# Altium schematic sheet ADC.SchDoc
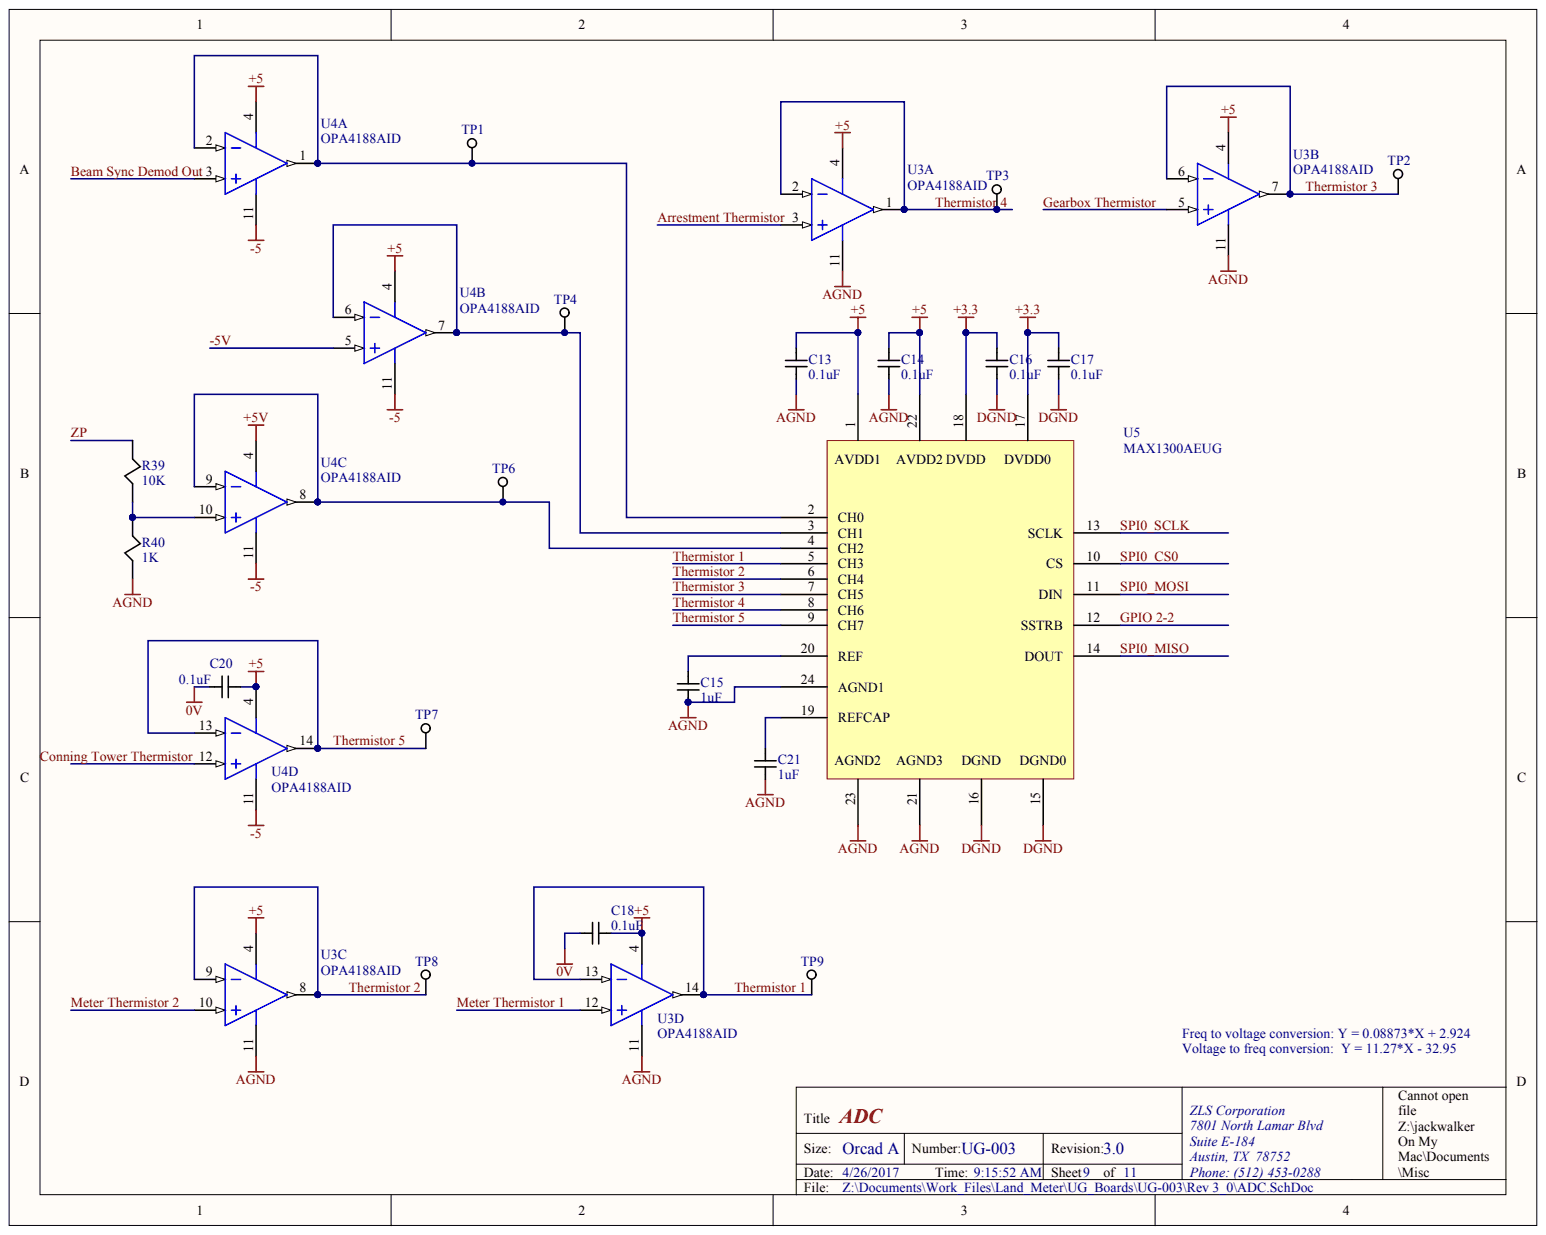
[diagram p0: ADC.SchDoc]

## Parts
C13  0.1uF
C14  0.1uF
C15  1uF
C16  0.1uF
C17  0.1uF
C18  0.1uF
C20  0.1uF
C21  1uF
R39  10K
R40  1K
TP1  (no value)
TP2  (no value)
TP3  (no value)
TP4  (no value)
TP6  (no value)
TP7  (no value)
TP8  (no value)
TP9  (no value)
U3  OPA4188AID  (parts A,B,C,D)
U4  OPA4188AID  (parts A,B,C,D)
U5  MAX1300AEUG

## Nets
0V: C18.1 C20.1
+3.3: C16.1 C17.1 U5.17 U5.18
+5: C13.1 C14.1 C18.2 C20.2 U3.4 U4.4 U5.1 U5.22
+5V: U4.4
-5: U4.11(-5)
AGND: C13.2 C14.2 C15.2 C21.2 R40.1 U3.11 U5.21 U5.23 U5.24(AGND1)
DGND: C16.2 C17.2 U5.15 U5.16
-5V: U4.5
ARRESTMENT THERMISTOR: U3.3
BEAM SYNC DEMOD OUT: U4.3
GEARBOX THERMISTOR: U3.5
GPIO 2-2: U5.12(SSTRB)
METER THERMISTOR 1: U3.12
METER THERMISTOR 2: U3.10
SPI0_CS0: U5.10(CS)
SPI0_MISO: U5.14(DOUT)
SPI0_MOSI: U5.11(DIN)
SPI0_SCLK: U5.13(SCLK)
THERMISTOR 1: TP9.1 U3.13 U3.14 U5.5(CH3)
THERMISTOR 2: TP8.1 U3.8 U3.9 U5.6(CH4)
THERMISTOR 3: TP2.1 U3.6 U3.7 U5.7(CH5)
THERMISTOR 4: TP3.1 U3.1 U3.2 U5.8(CH6)
THERMISTOR 5: TP7.1 U4.13 U4.14 U5.9(CH7)
ZP: R39.2
NetC15_1: C15.1 U5.20(REF)
NetC21_1: C21.1 U5.19(REFCAP)
NetR39_1: R39.1 R40.2 U4.10
NetTP1_1: TP1.1 U4.1 U4.2 U5.2(CH0)
NetTP4_1: TP4.1 U4.6 U4.7 U5.3(CH1)
NetTP6_1: TP6.1 U4.8 U4.9 U5.4(CH2)
CONNING TOWER THERMISTOR:

Not connected: U4 12

## Library data of the parts
C13 C14 C16-C18 C20 = (no symbol name): Manufacturer 1: Murata Electronics North America; Manufacturer Part Number 1: GRM21BR71E104KA01L; Description 1: CAP CER 0.1UF 25V X7R 0805; Category 1: Capacitors; Capacitance: 0.1µF; Tolerance: ±10%; Voltage - Rated: 25V; Temperature Coefficient: X7R; Supplier 1: Digi-Key; Supplier Part Number 1: 490-1673-1-ND; RoHS 1: RoHS Compliant; Stock 1: 897437; Pricing 1: 1=0.2, 10=0.145, 25=0.106, 50=0.0824, 100=0.0683, 250=0.05652, 500=0.04788, 1000=0.03964 (USD); Packaging: Cut Tape (CT); Part Status: Active; Operating Temperature: -55°C ~ 125°C; Features: -; Ratings: -; Applications: General Purpose; Mounting Type: Surface Mount, MLCC; Package / Case: 0805 (2012 Metric); Size / Dimension: 0.079" L x 0.049" W (2.00mm x 1.25mm); Height - Seated (Max): -; Thickness (Max): 0.053" (1.35mm); Lead Spacing: -; Lead Style: -; ComponentLink1URL: http://www.murata.com/~/media/webrenewal/support/library/catalog/products/capacitor/mlcc/c02e.ashx; ComponentLink1Description: http://www.murata.com/~/media/webrenewal/support/library/catalog/products/capacitor/mlcc/c02e.ashx
C15 C21 = (no symbol name): Manufacturer 1: Murata Electronics North America; Manufacturer Part Number 1: GRM219R71C104KA01D; Description 1: CAP CER 0.1UF 16V X7R 0805; Category 1: Capacitors; Capacitance: 0.1µF; Tolerance: ±10%; Voltage - Rated: 16V; Temperature Coefficient: X7R; Supplier 1: Digi-Key; Supplier Part Number 1: 490-1683-1-ND; RoHS 1: RoHS Compliant; Stock 1: 267626; Pricing 1: 1=0.13, 10=0.095, 25=0.0688, 50=0.0536, 100=0.0445, 250=0.0368, 500=0.03118, 1000=0.02581 (USD); Packaging: Cut Tape (CT); Part Status: Active; Operating Temperature: -55°C ~ 125°C; Features: -; Ratings: -; Applications: General Purpose; Mounting Type: Surface Mount, MLCC; Package / Case: 0805 (2012 Metric); Size / Dimension: 0.079" L x 0.049" W (2.00mm x 1.25mm); Height - Seated (Max): -; Thickness (Max): 0.037" (0.95mm); Lead Spacing: -; Lead Style: -; ComponentLink1URL: http://www.murata.com/~/media/webrenewal/support/library/catalog/products/capacitor/mlcc/c02e.ashx; ComponentLink1Description: http://www.murata.com/~/media/webrenewal/support/library/catalog/products/capacitor/mlcc/c02e.ashx
R39 = (no symbol name): Manufacturer 1: Vishay Dale; Manufacturer Part Number 1: CRCW080510K0FKTA; Description 1: RES SMD 10K OHM 1% 1/8W 0805; Category 1: Resistors; Tolerance: ±1%; Power (Watts): 0.125W, 1/8W; Temperature Coefficient: ±100ppm/°C; Supplier 1: Digi-Key; Supplier Part Number 1: 541-3016-1-ND; RoHS 1: RoHS non-compliant; Stock 1: 11388; Pricing 1: 1=0.14, 10=0.123, 25=0.0852, 50=0.0662, 100=0.0474, 250=0.03692, 500=0.02936, 1000=0.02084 (USD); Packaging: Cut Tape (CT); Part Status: Active; Resistance (Ohms): 10k; Composition: Thick Film; Features: Automotive AEC-Q200; Operating Temperature: -55°C ~ 155°C; Package / Case: 0805 (2012 Metric); Supplier Device Package: 0805; Size / Dimension: 0.079" L x 0.049" W (2.00mm x 1.25mm); Height - Seated (Max): 0.020" (0.50mm); Failure Rate: -; ComponentLink1URL: http://www.vishay.com/docs/20008/dcrcw.pdf; ComponentLink1Description: http://www.vishay.com/docs/20008/dcrcw.pdf
R40 = (no symbol name): Manufacturer 1: Vishay Dale; Manufacturer Part Number 1: CRCW080510K0FKEC; Description 1: RES SMD 10K OHM 1% 1/8W 0805; Category 1: Resistors; Resistance (Ohms): 10k; Tolerance: ±1%; Power (Watts): 0.125W, 1/8W; Temperature Coefficient: ±100ppm/°C; Supplier 1: Digi-Key; Supplier Part Number 1: 541-3015-1-ND; RoHS 1: RoHS Compliant; Stock 1: 13410; Pricing 1: 1=0.1, 10=0.042, 25=0.0304, 50=0.0232, 100=0.017, 250=0.013, 500=0.0104, 1000=0.00765, 5000=0.00549 (USD); Packaging: Cut Tape (CT); Part Status: Active; Composition: Thick Film; Features: Automotive AEC-Q200; Operating Temperature: -55°C ~ 155°C; Package / Case: 0805 (2012 Metric); Supplier Device Package: 0805; Size / Dimension: 0.079" L x 0.049" W (2.00mm x 1.25mm); Height - Seated (Max): 0.020" (0.50mm); Failure Rate: -; ComponentLink1URL: http://www.vishay.com/docs/20035/dcrcwe3.pdf; ComponentLink1Description: http://www.vishay.com/docs/20035/dcrcwe3.pdf
TP1-TP4 TP6-TP9 = (no symbol name): Manufacturer 1: Keystone Electronics; Manufacturer Part Number 1: 5001; Description 1: TEST POINT PC MINI .040"D BLACK; Category 1: Test and Measurement; Plating: Silver; Material - Insulation: Nylon 46, UL 94V-0; Material - Body: Phosphor Bronze; Supplier 1: Digi-Key; Supplier Part Number 1: 36-5001-ND; RoHS 1: RoHS Compliant; Stock 1: 883882; Pricing 1: 1=0.37, 10=0.316, 50=0.2298, 100=0.2209, 250=0.19832, 500=0.18932, 1000=0.1616, 2500=0.14424, 5000=0.13523 (USD); Packaging: Bulk; Part Status: Active; Type: PC Test Point, Miniature; Mounting Type: 0.040" (1.02mm) Hole Diameter; Color: Black; Size / Dimension: 0.100" Dia x 0.180" L (2.54mm x 4.57mm); Height: -; ComponentLink1URL: http://www.keyelco.com/product-pdf.cfm?p=1310; ComponentLink1Description: http://www.keyelco.com/product-pdf.cfm?p=1310
U3 U4 = (no symbol name): Manufacturer: Texas Instruments; CMRR(Min)(dB): 120; Eco-Plan: Green (RoHS & no Sb/Br); GBW(Typ)(MHz): 2; Iq per channel(Max)(mA): 0.475; Number of Channels: 4; Offset Drift(Typ)(uV/C): 0.03; Packing: TUBE; Rating: Catalog; Slew Rate(Typ)(V/us): 0.8; SubFamily: High Supply Voltage Amplifier (>=30V), Low Noise Amp (<=10nV/rtHz), Low Offset Voltage Amplifier (<=500uV), Low Power Amp (<=500uA), Zero Drift Precision Amplifier; Total Supply Voltage(Max)(+5V is 5, +/-5V is 10): 36; DatasheetVersion: SBOS641B; PackageVersion: 4040047-5/M, 06/2011; PackageReference: D14; PackageDescription: 14-Pin Plastic Small Outline, Body 8.65 x 3.9 mm, Pitch 1.27 mm; Supplier 1: Digi-Key; Supplier Part Number 1: 296-34857-5-ND; Supplier 2: Farnell; Supplier Part Number 2: 2211327; Supplier 3: Newark; Supplier Part Number 3: 44W4374; Supplier 4: Newark; Supplier Part Number 4: 27W9605; Supplier 5: Mouser; Supplier Part Number 5: 595-OPA4188AID; Total Supply Voltage(Min)(+5V is 5, +/-5V is 10): 4; Mounting Technology: Surface Mount; Code_JEDEC: MS-012; PartNumber: OPA4188AID; ComponentLink1Description: Manufacturer URL; ComponentLink1URL: http://www.ti.com/; ComponentLink2Description: Datasheet; ComponentLink2URL: http://www.ti.com/lit/ds/symlink/opa4188.pdf; ComponentLink3Description: Package Specification; ComponentLink3URL: http://www.ti.com/lit/ml/mpds177g/mpds177g.pdf
U5 = (no symbol name): Manufacturer 1: Maxim Integrated; Manufacturer Part Number 1: MAX1300AEUG+; Description 1: IC ADC 16BIT SRL 115KSPS 24TSSOP; Category 1: Integrated Circuits (ICs); Number of Bits: 16; Sampling Rate (Per Second): 115k; Number of Inputs: 4, 8; Input Type: Differential, Single Ended; Data Interface: SPI; Configuration: MUX-PGA-S/H-ADC; Ratio - S/H:ADC: 1:1; Number of A/D Converters: 1; Architecture: SAR; Reference Type: External, Internal; Voltage - Supply, Analog: 5V; Supplier 2: Mouser; Supplier Part Number 2: 700-MAX1300AEUG+; Supplier 1: Digi-Key; Supplier Part Number 1: MAX1300AEUG+-ND; RoHS 1: RoHS Compliant; Stock 1: 1553; Pricing 1: 1=13.94, 10=13.24, 50=13.16 (USD); Packaging: Tube; Part Status: Active; Voltage - Supply, Digital: 5V; Features: PGA; Operating Temperature: -40°C ~ 85°C; Package / Case: 24-TSSOP (0.173", 4.40mm Width); Supplier Device Package: 24-TSSOP; ComponentLink1URL: http://datasheets.maximintegrated.com/en/ds/MAX1300-MAX1301.pdf; ComponentLink1Description: http://datasheets.maximintegrated.com/en/ds/MAX1300-MAX1301.pdf
